# Altium schematic sheet audio-amp.SchDoc
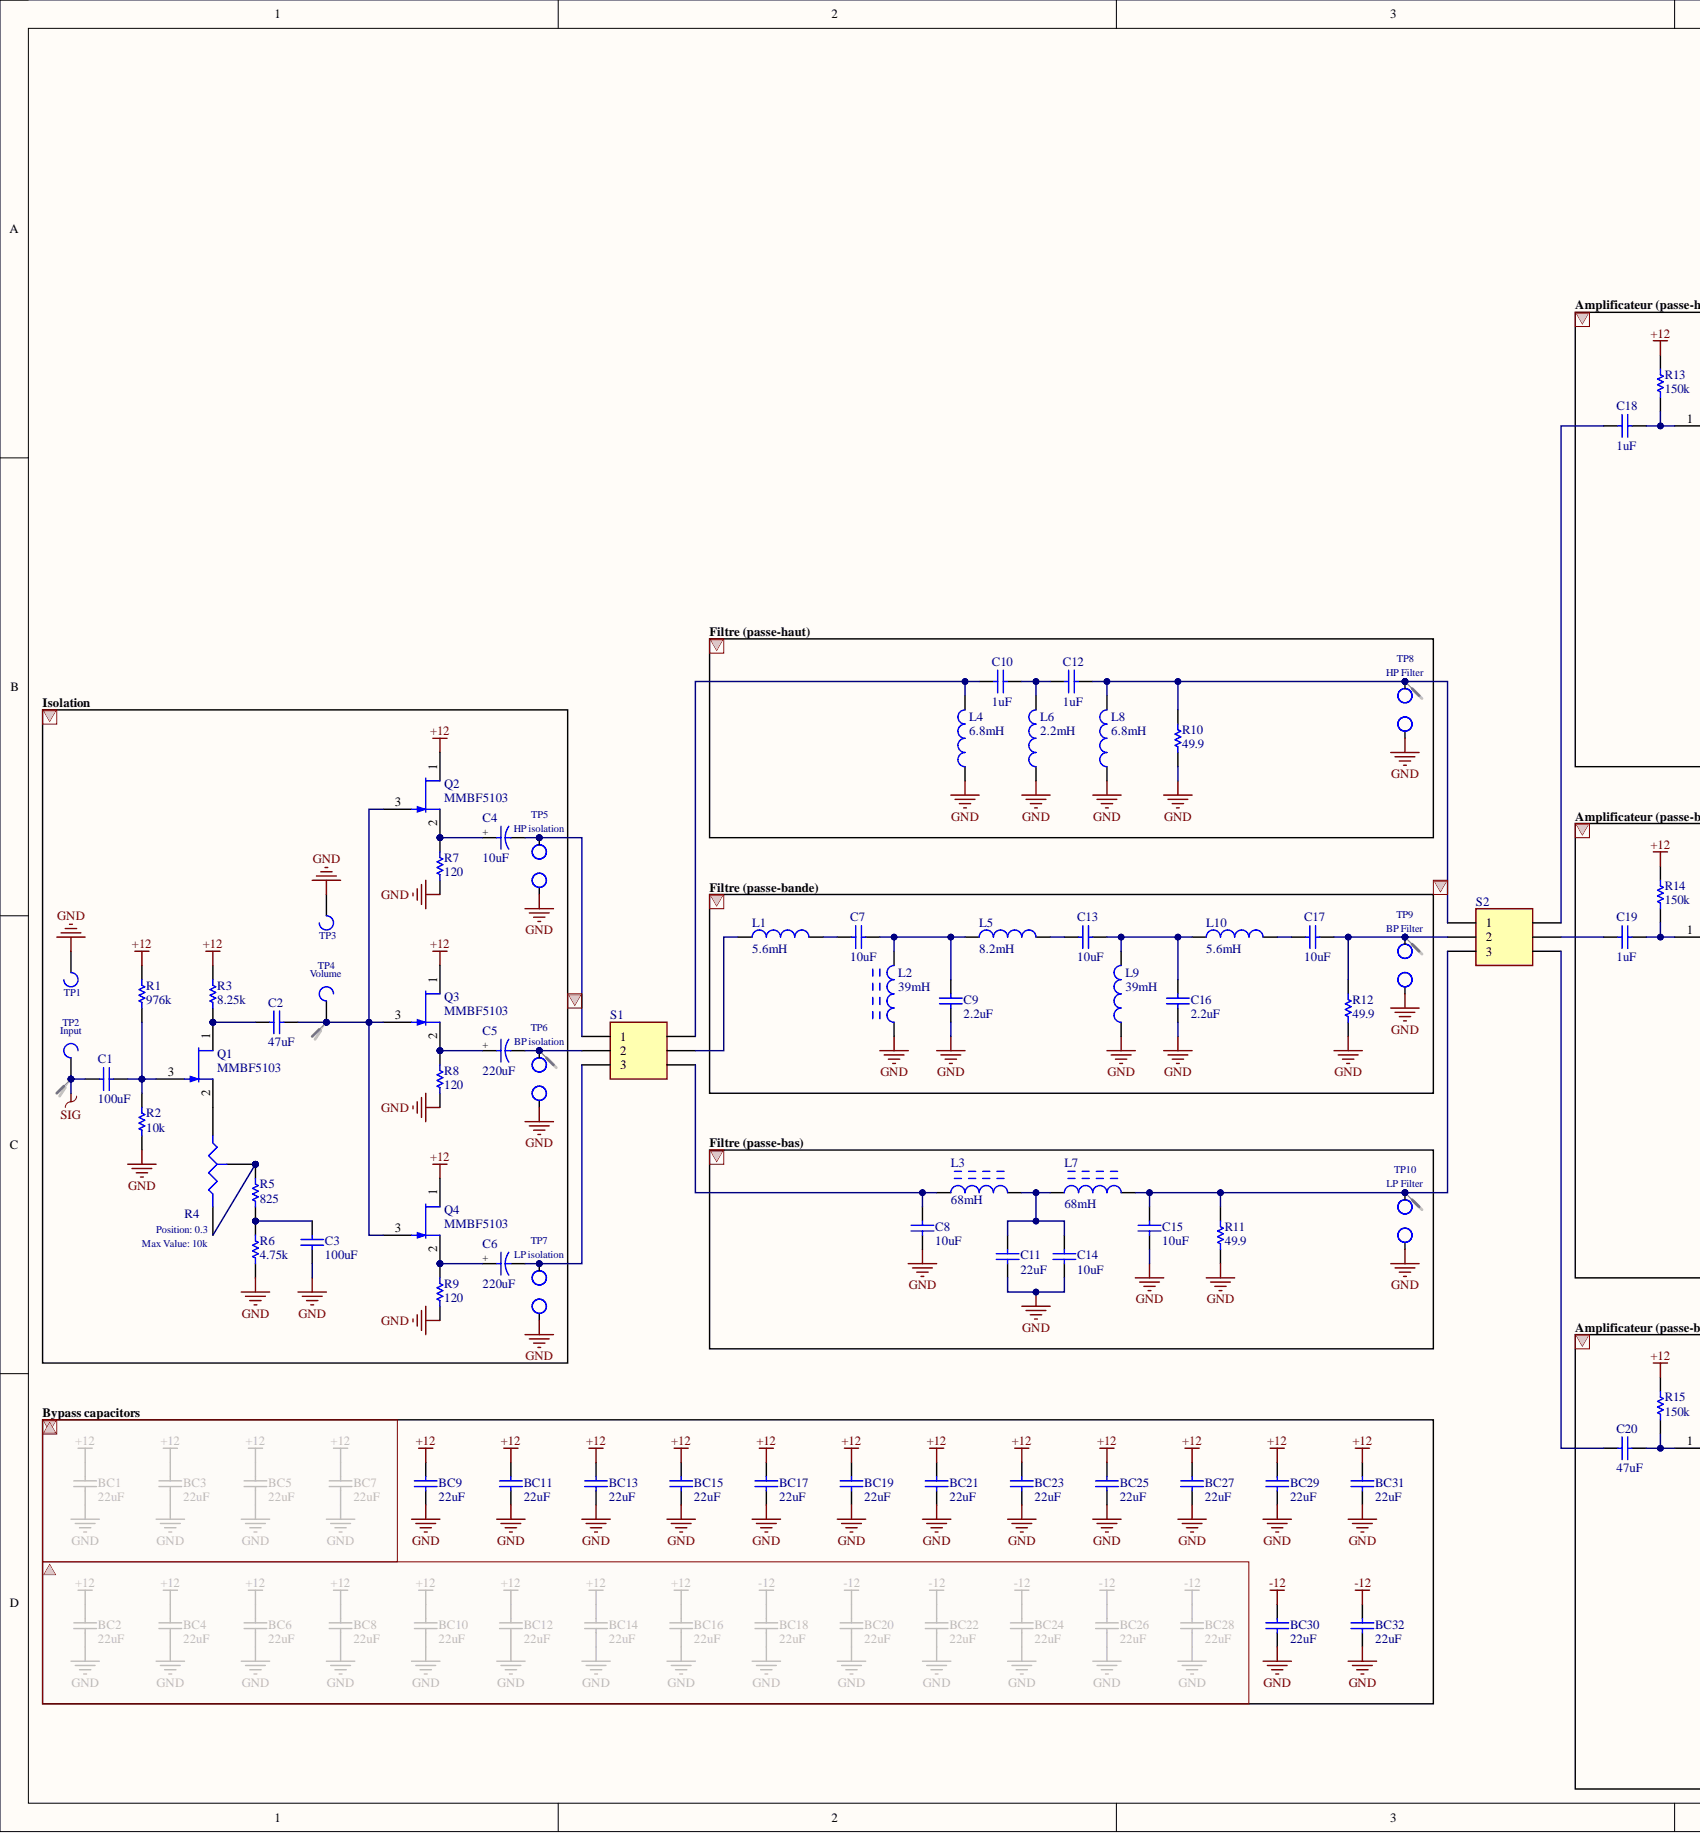
[diagram p0: audio-amp.SchDoc, left]
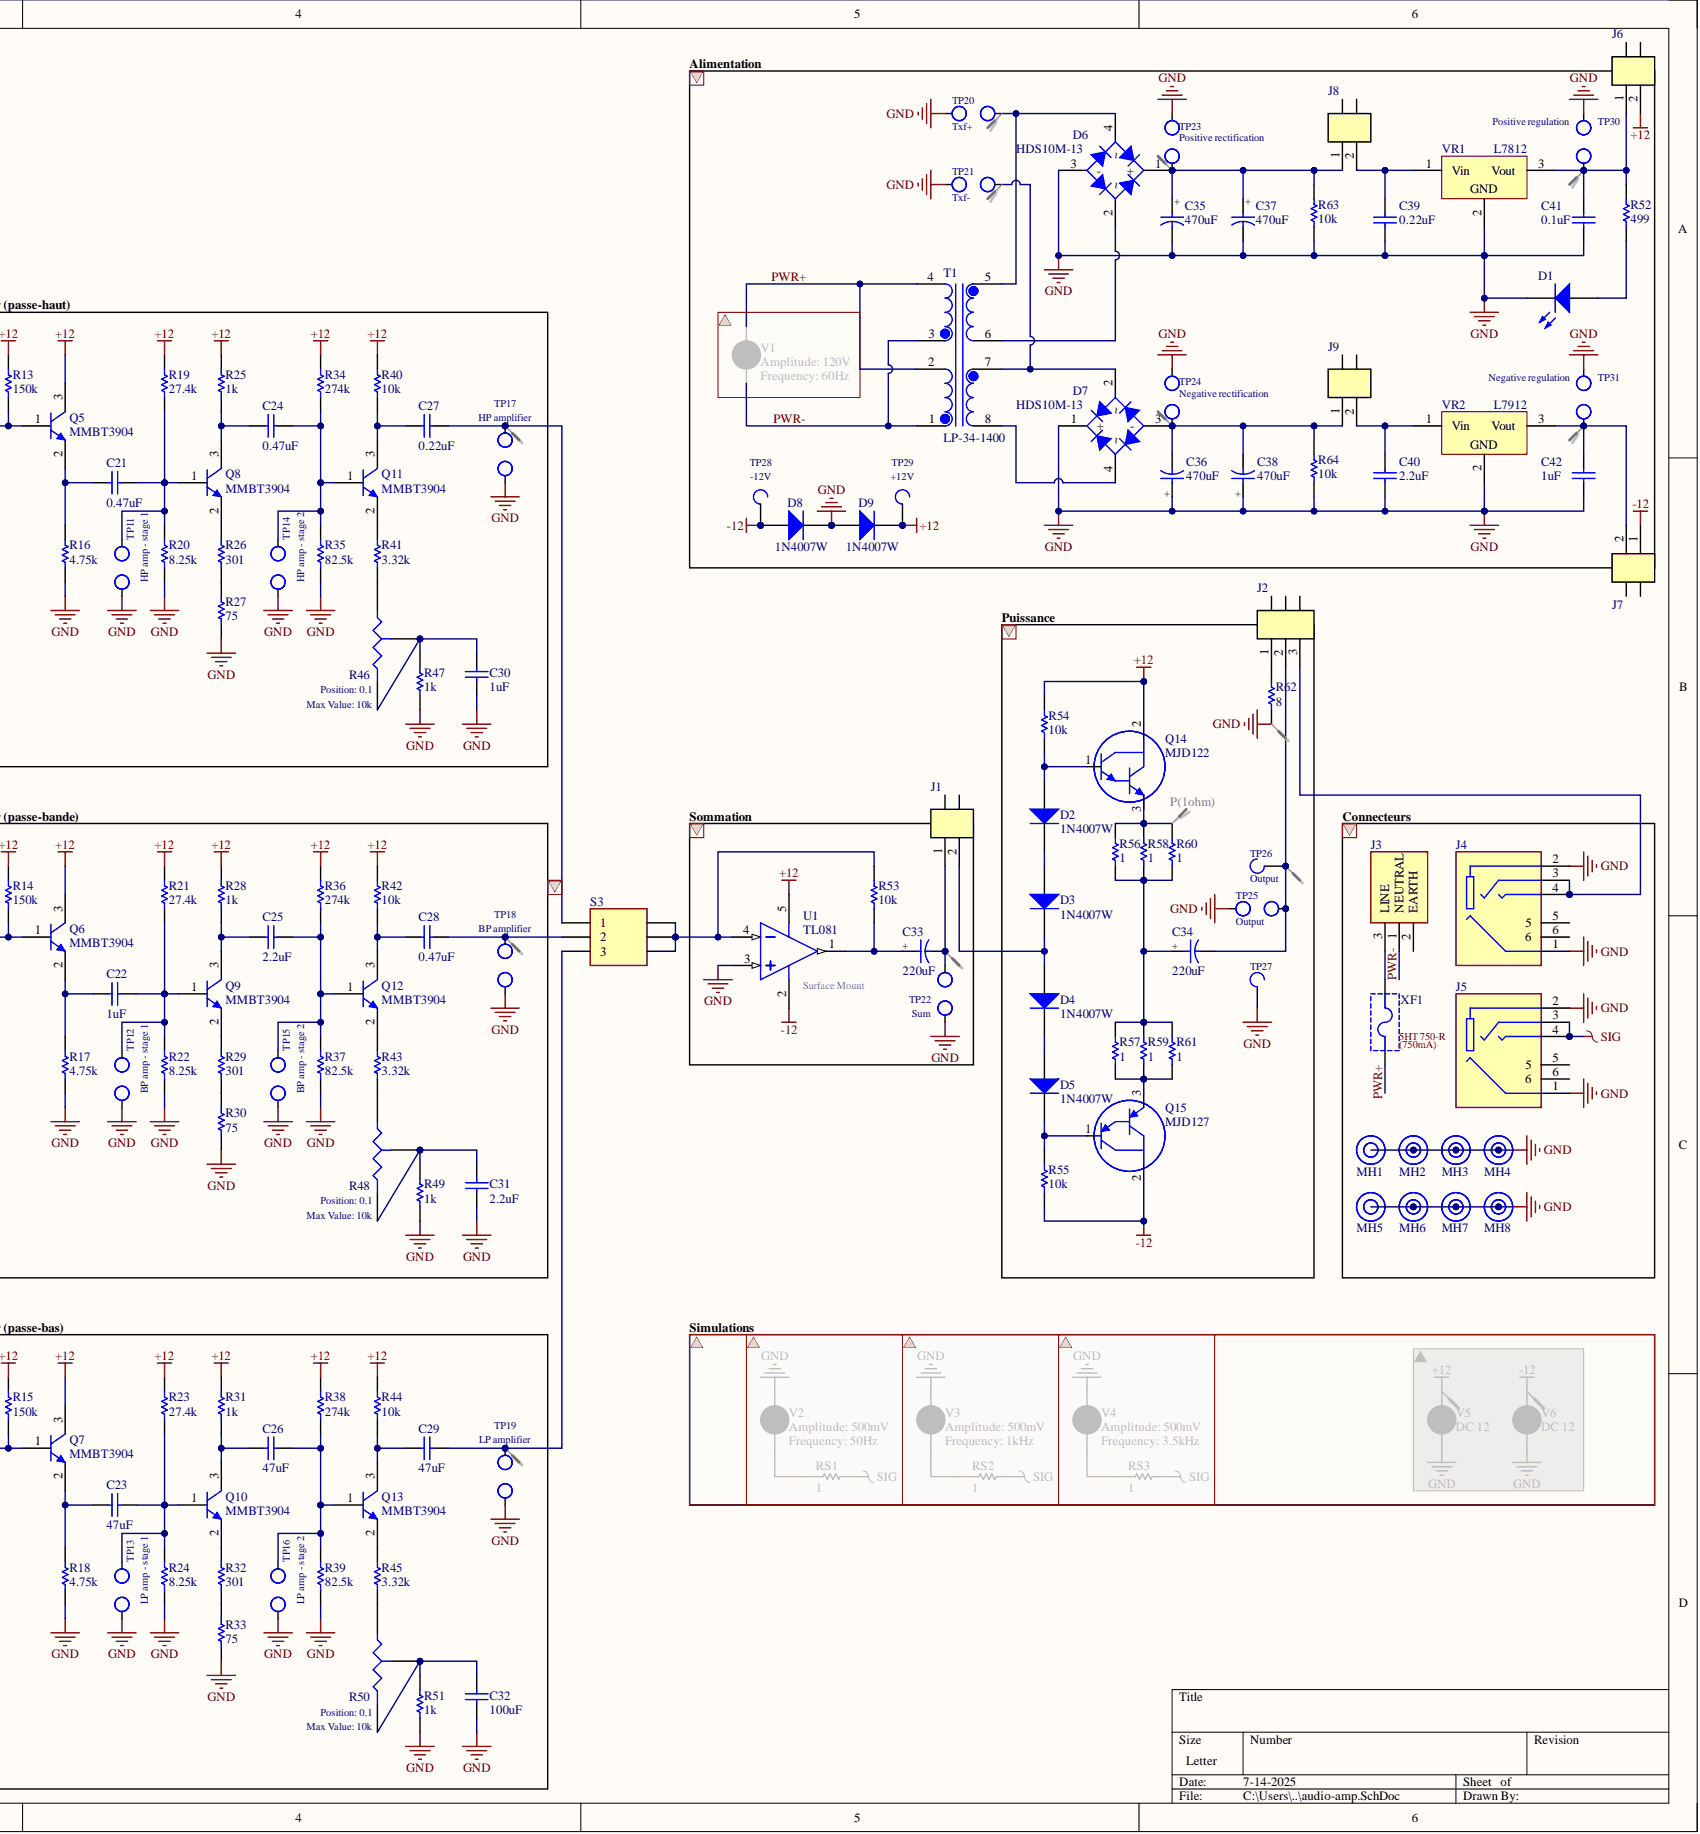
[diagram p1: audio-amp.SchDoc, right]

## Parts
BC9  value 22uF  [SMD-0805-M]  (hidden comment: Cap-SM-M)
BC11  value 22uF  [SMD-0805-M]  (hidden comment: Cap-SM-M)
BC13  value 22uF  [SMD-0805-M]  (hidden comment: Cap-SM-M)
BC15  value 22uF  [SMD-0805-M]  (hidden comment: Cap-SM-M)
BC17  value 22uF  [SMD-0805-M]  (hidden comment: Cap-SM-M)
BC19  value 22uF  [SMD-0805-M]  (hidden comment: Cap-SM-M)
BC21  value 22uF  [SMD-0805-M]  (hidden comment: Cap-SM-M)
BC23  value 22uF  [SMD-0805-M]  (hidden comment: Cap-SM-M)
BC25  value 22uF  [SMD-0805-M]  (hidden comment: Cap-SM-M)
BC27  value 22uF  [SMD-0805-M]  (hidden comment: Cap-SM-M)
BC29  value 22uF  [SMD-0805-M]  (hidden comment: Cap-SM-M)
BC30  value 22uF  [SMD-0805-M]  (hidden comment: Cap-SM-M)
BC31  value 22uF  [SMD-0805-M]  (hidden comment: Cap-SM-M)
BC32  value 22uF  [SMD-0805-M]  (hidden comment: Cap-SM-M)
C1  value 100uF  [SMD-1210-M]  (hidden comment: Cap-SM-M)
C2  value 47uF  [SMD-1210-M]  (hidden comment: Cap-SM-M)
C3  value 100uF  [SMD-1210-M]  (hidden comment: Cap-SM-M)
C4  value 10uF  [CAP-APA0606]  (hidden comment: Cap2-10uF-SM)
C5  value 220uF  [CAP-A768KS-M]  (hidden comment: Cap2-220uF-SM)
C6  value 220uF  [CAP-A768KS-M]  (hidden comment: Cap2-220uF-SM)
C7  value 10uF  [SMD-0805-M]  (hidden comment: Cap-SM-M)
C8  value 10uF  [SMD-0805-M]  (hidden comment: Cap-SM-M)
C9  value 2.2uF  [SMD-0805-M]  (hidden comment: Cap-SM-M)
C10  value 1uF  [SMD-0603-M]  (hidden comment: Cap-SM-M)
C11  value 22uF  [SMD-0805-M]  (hidden comment: Cap-SM-M)
C12  value 1uF  [SMD-0603-M]  (hidden comment: Cap-SM-M)
C13  value 10uF  [SMD-0805-M]  (hidden comment: Cap-SM-M)
C14  value 10uF  [SMD-0805-M]  (hidden comment: Cap-SM-M)
C15  value 10uF  [SMD-0805-M]  (hidden comment: Cap-SM-M)
C16  value 2.2uF  [SMD-0805-M]  (hidden comment: Cap-SM-M)
C17  value 10uF  [SMD-0805-M]  (hidden comment: Cap-SM-M)
C18  value 1uF  [SMD-0603-M]  (hidden comment: Cap-SM-M)
C19  value 1uF  [SMD-0603-M]  (hidden comment: Cap-SM-M)
C20  value 47uF  [SMD-1210-M]  (hidden comment: Cap-SM-M)
C21  value 0.47uF  [SMD-0805-M]  (hidden comment: Cap-SM-M)
C22  value 1uF  [SMD-0603-M]  (hidden comment: Cap-SM-M)
C23  value 47uF  [SMD-1210-M]  (hidden comment: Cap-SM-M)
C24  value 0.47uF  [SMD-0805-M]  (hidden comment: Cap-SM-M)
C25  value 2.2uF  [SMD-0805-M]  (hidden comment: Cap-SM-M)
C26  value 47uF  [SMD-1210-M]  (hidden comment: Cap-SM-M)
C27  value 0.22uF  [SMD-0805-M]  (hidden comment: Cap-SM-M)
C28  value 0.47uF  [SMD-0805-M]  (hidden comment: Cap-SM-M)
C29  value 47uF  [SMD-1210-M]  (hidden comment: Cap-SM-M)
C30  value 1uF  [SMD-0603-M]  (hidden comment: Cap-SM-M)
C31  value 2.2uF  [SMD-0805-M]  (hidden comment: Cap-SM-M)
C32  value 100uF  [SMD-1210-M]  (hidden comment: Cap-SM-M)
C33  value 220uF  [CAP-A768KS-M]  (hidden comment: Cap2-220uF-SM)
C34  value 220uF  [CAP-A768KS-M]  (hidden comment: Cap2-220uF-SM)
C35  value 470uF  [CAP-APD1012]  (hidden comment: Cap2-470uF-SM)
C36  value 470uF  [CAP-APD1012]  (hidden comment: Cap2-470uF-SM)
C37  value 470uF  [CAP-APD1012]  (hidden comment: Cap2-470uF-SM)
C38  value 470uF  [CAP-APD1012]  (hidden comment: Cap2-470uF-SM)
C39  value 0.22uF  [SMD-0805-M]  (hidden comment: Cap-SM-M)
C40  value 2.2uF  [SMD-0805-M]  (hidden comment: Cap-SM-M)
C41  value 0.1uF  [SMD-0805-M]  (hidden comment: Cap-SM-M)
C42  value 1uF  [SMD-0603-M]  (hidden comment: Cap-SM-M)
D1  LED  [LED_RED]  (value not drawn)
D2  1N4007W  [SOD-123FL]
D3  1N4007W  [SOD-123FL]
D4  1N4007W  [SOD-123FL]
D5  1N4007W  [SOD-123FL]
D6  HDS10M-13  [HDS10M13]
D7  HDS10M-13  [HDS10M13]
D8  1N4007W  [SOD-123FL]
D9  1N4007W  [SOD-123FL]
J1  HDR2x1  [HDR_1X2]  (value not drawn)
J2  HDR3x1  [HDR_1X3]  (value not drawn)
J3  703W-00/53  [QUALTEK_703W-00/53]  (value not drawn)
J4  Audio-Jack-Input  [Audio-Jack-Input]  (value not drawn)
J5  Audio-Jack-Input  [Audio-Jack-Input]  (value not drawn)
J6  HDR2x1  [HDR_1X2]  (value not drawn)
J7  HDR2x1  [HDR_1X2]  (value not drawn)
J8  HDR2x1  [HDR_1X2]  (value not drawn)
J9  HDR2x1  [HDR_1X2]  (value not drawn)
L1  value 5.6mH  [IND-9MM]  (hidden comment: Inductor)
L2  value 39mH  [TX-1779-1567-ND]  (hidden comment: Ind-Ferrite)
L3  value 68mH  [TX-1779-1567-ND]  (hidden comment: Ind-Ferrite)
L4  value 6.8mH  [IND-12MM]  (hidden comment: Inductor)
L5  value 8.2mH  [IND-9MM]  (hidden comment: Inductor)
L6  value 2.2mH  [IND-12MM]  (hidden comment: Inductor)
L7  value 68mH  [TX-1779-1567-ND]  (hidden comment: Ind-Ferrite)
L8  value 6.8mH  [IND-12MM]  (hidden comment: Inductor)
L9  value 39mH  [IND-9MM]  (hidden comment: Inductor)
L10  value 5.6mH  [IND-9MM]  (hidden comment: Inductor)
MH1  Mounting-Hole  [MH]  (value not drawn)
MH2  Mounting-Hole  [MH]  (value not drawn)
MH3  Mounting-Hole  [MH]  (value not drawn)
MH4  Mounting-Hole  [MH]  (value not drawn)
MH5  Mounting-Hole  [MH]  (value not drawn)
MH6  Mounting-Hole  [MH]  (value not drawn)
MH7  Mounting-Hole  [MH]  (value not drawn)
MH8  Mounting-Hole  [MH]  (value not drawn)
Q1  MMBF5103  [SOT-23-3]
Q2  MMBF5103  [SOT-23-3]
Q3  MMBF5103  [SOT-23-3]
Q4  MMBF5103  [SOT-23-3]
Q5  MMBT3904  [SOT-23-3]
Q6  MMBT3904  [SOT-23-3]
Q7  MMBT3904  [SOT-23-3]
Q8  MMBT3904  [SOT-23-3]
Q9  MMBT3904  [SOT-23-3]
Q10  MMBT3904  [SOT-23-3]
Q11  MMBT3904  [SOT-23-3]
Q12  MMBT3904  [SOT-23-3]
Q13  MMBT3904  [SOT-23-3]
Q14  MJD122  [TO-252-2L+HSS-C52]
Q15  MJD127  [TO-252-2L+HSS-C52]
R1  value 976k  [SMD-0805-M]  (hidden comment: Res-SM-M)
R2  value 10k  [SMD-1206-M]  (hidden comment: Res-SM-M)
R3  value 8.25k  [SMD-0805-M]  (hidden comment: Res-SM-M)
R4  Max Value: 10k  Position: 0.3  [TRIM_3361P]  (hidden comment: Potentiometer_SM)
R5  value 825  [SMD-0805-M]  (hidden comment: Res-SM-M)
R6  value 4.75k  [SMD-0805-M]  (hidden comment: Res-SM-M)
R7  value 120  [SMD-1206-M]  (hidden comment: Res-SM-M)
R8  value 120  [SMD-1206-M]  (hidden comment: Res-SM-M)
R9  value 120  [SMD-1206-M]  (hidden comment: Res-SM-M)
R10  value 49.9  [SMD-0805-M]  (hidden comment: Res-SM-M)
R11  value 49.9  [SMD-0805-M]  (hidden comment: Res-SM-M)
R12  value 49.9  [SMD-0805-M]  (hidden comment: Res-SM-M)
R13  value 150k  [SMD-1206-M]  (hidden comment: Res-SM-M)
R14  value 150k  [SMD-1206-M]  (hidden comment: Res-SM-M)
R15  value 150k  [SMD-1206-M]  (hidden comment: Res-SM-M)
R16  value 4.75k  [SMD-0805-M]  (hidden comment: Res-SM-M)
R17  value 4.75k  [SMD-0805-M]  (hidden comment: Res-SM-M)
R18  value 4.75k  [SMD-0805-M]  (hidden comment: Res-SM-M)
R19  value 27.4k  [SMD-1206-M]  (hidden comment: Res-SM-M)
R20  value 8.25k  [SMD-0805-M]  (hidden comment: Res-SM-M)
R21  value 27.4k  [SMD-1206-M]  (hidden comment: Res-SM-M)
R22  value 8.25k  [SMD-0805-M]  (hidden comment: Res-SM-M)
R23  value 27.4k  [SMD-1206-M]  (hidden comment: Res-SM-M)
R24  value 8.25k  [SMD-0805-M]  (hidden comment: Res-SM-M)
R25  value 1k  [SMD-0805-M]  (hidden comment: Res-SM-M)
R26  value 301  [SMD-0805-M]  (hidden comment: Res-SM-M)
R27  value 75  [SMD-0805-M]  (hidden comment: Res-SM-M)
R28  value 1k  [SMD-0805-M]  (hidden comment: Res-SM-M)
R29  value 301  [SMD-0805-M]  (hidden comment: Res-SM-M)
R30  value 75  [SMD-0805-M]  (hidden comment: Res-SM-M)
R31  value 1k  [SMD-0805-M]  (hidden comment: Res-SM-M)
R32  value 301  [SMD-0805-M]  (hidden comment: Res-SM-M)
R33  value 75  [SMD-0805-M]  (hidden comment: Res-SM-M)
R34  value 274k  [SMD-0805-M]  (hidden comment: Res-SM-M)
R35  value 82.5k  [SMD-1206-M]  (hidden comment: Res-SM-M)
R36  value 274k  [SMD-0805-M]  (hidden comment: Res-SM-M)
R37  value 82.5k  [SMD-1206-M]  (hidden comment: Res-SM-M)
R38  value 274k  [SMD-0805-M]  (hidden comment: Res-SM-M)
R39  value 82.5k  [SMD-1206-M]  (hidden comment: Res-SM-M)
R40  value 10k  [SMD-1206-M]  (hidden comment: Res-SM-M)
R41  value 3.32k  [SMD-0805-M]  (hidden comment: Res-SM-M)
R42  value 10k  [SMD-1206-M]  (hidden comment: Res-SM-M)
R43  value 3.32k  [SMD-0805-M]  (hidden comment: Res-SM-M)
R44  value 10k  [SMD-1206-M]  (hidden comment: Res-SM-M)
R45  value 3.32k  [SMD-0805-M]  (hidden comment: Res-SM-M)
R46  Max Value: 10k  Position: 0.1  [TRIM_3361P]  (hidden comment: Potentiometer_SM)
R47  value 1k  [SMD-0805-M]  (hidden comment: Res-SM-M)
R48  Max Value: 10k  Position: 0.1  [TRIM_3361P]  (hidden comment: Potentiometer_SM)
R49  value 1k  [SMD-0805-M]  (hidden comment: Res-SM-M)
R50  Max Value: 10k  Position: 0.1  [TRIM_3361P]  (hidden comment: Potentiometer_SM)
R51  value 1k  [SMD-0805-M]  (hidden comment: Res-SM-M)
R52  value 499  [SMD-0805-M]  (hidden comment: Res-SM-M)
R53  value 10k  [SMD-1206-M]  (hidden comment: Res-SM-M)
R54  value 10k  [SMD-1206-M]  (hidden comment: Res-SM-M)
R55  value 10k  [SMD-1206-M]  (hidden comment: Res-SM-M)
R56  value 1  [SMD-0805-M]  (hidden comment: Res-SM-M)
R57  value 1  [SMD-0805-M]  (hidden comment: Res-SM-M)
R58  value 1  [SMD-0805-M]  (hidden comment: Res-SM-M)
R59  value 1  [SMD-0805-M]  (hidden comment: Res-SM-M)
R60  value 1  [SMD-0805-M]  (hidden comment: Res-SM-M)
R61  value 1  [SMD-0805-M]  (hidden comment: Res-SM-M)
R62  value 8  [RES-2W]  (hidden comment: Res)
R63  value 10k  [SMD-1206-M]  (hidden comment: Res-SM-M)
R64  value 10k  [SMD-1206-M]  (hidden comment: Res-SM-M)
S1  219-3MSTR  [2193MSTR]  (value not drawn)
S2  219-3MSTR  [2193MSTR]  (value not drawn)
S3  219-3MSTR  [2193MSTR]  (value not drawn)
T1  LP-34-1400  [LP341400]
TP1  Comment  [KEYSTONE_5002_Black]  (value not drawn)
TP2  Input  [KEYSTONE_5002_White]
TP3  Comment  [KEYSTONE_5002_Black]  (value not drawn)
TP4  Volume  [KEYSTONE_5002_White]
TP5  HP isolation  [TP-Pad-Ref]
TP6  BP isolation  [TP-Pad-Ref]
TP7  LP isolation  [TP-Pad-Ref]
TP8  HP Filter  [TP-Pad-Ref]
TP9  BP Filter  [TP-Pad-Ref]
TP10  LP Filter  [TP-Pad-Ref]
TP11  HP amp - stage 1  [TP-Pad-Ref]
TP12  BP amp - stage 1  [TP-Pad-Ref]
TP13  LP amp - stage 1  [TP-Pad-Ref]
TP14  HP amp - stage 2  [TP-Pad-Ref]
TP15  BP amp - stage 2  [TP-Pad-Ref]
TP16  LP amp - stage 2  [TP-Pad-Ref]
TP17  HP amplifier  [TP-Pad-Ref]
TP18  BP amplifier  [TP-Pad-Ref]
TP19  LP amplifier  [TP-Pad-Ref]
TP20  Txf+  [TP-Pad-Ref]
TP21  Txf-  [TP-Pad-Ref]
TP22  Sum  [TP-Pad-Ref]
TP23  Positive rectification  [TP-Pad-Ref]
TP24  Negative rectification  [TP-Pad-Ref]
TP25  Output  [TP-Pad-Ref]
TP26  Output  [KEYSTONE_5002_White]
TP27  Comment  [KEYSTONE_5002_Black]  (value not drawn)
TP28  -12V  [KEYSTONE_5002_Yellow]
TP29  +12V  [KEYSTONE_5002_Red]
TP30  Positive regulation  [TP-Pad-Ref]
TP31  Negative regulation  [TP-Pad-Ref]
U1  TL081  MountingType: Surface Mount  [SOT-23-5]
VR1  L7812  [TO-263AB+HSS-C2591]
VR2  L7912  [TO-263AB+HSS-C2591]
XF1  696310001002  [696310001002]  (value not drawn)

## Nets
+12: BC9.2 BC11.2 BC13.2 BC15.2 BC17.2 BC19.2 BC21.2 BC23.2 BC25.2 BC27.2 BC29.2 BC31.2 D9.1 J6.2 Q2.1 Q3.1 Q4.1 Q5.3 Q6.3 Q7.3 Q14.2 R1.2 R3.2 R13.2 R14.2 R15.2 R19.2 R21.2 R23.2 R25.2 R28.2 R31.2 R34.2 R36.2 R38.2 R40.2 R42.2 R44.2 R54.2 TP29.TP U1.5
-12: BC30.2 BC32.2 D8.2 J7.1 Q15.2 R55.1 TP28.TP U1.2
GND: BC9.1 BC11.1 BC13.1 BC15.1 BC17.1 BC19.1 BC21.1 BC23.1 BC25.1 BC27.1 BC29.1 BC30.1 BC31.1 BC32.1 C3.1 C8.1 C9.1 C11.1 C14.1 C15.1 C16.1 C30.1 C31.1 C32.2 C35.2 C36.1 C37.2 C38.1 C39.1 C40.1 C41.1 C42.1 D1.2 D6.3(-) D7.1(+) D8.1 D9.2 J4.1 J4.2 J5.1 J5.2 L2.1 L4.1 L6.1 L8.1 L9.1 MH1.1 MH2.1 MH3.1 MH4.1 MH5.1 MH6.1 MH7.1 MH8.1 R2.1 R6.1 R7.1 R8.1 R9.1 R10.1 R11.1 R12.1 R16.1 R17.1 R18.1 R20.1 R22.1 R24.1 R27.1 R30.1 R33.1 R35.1 R37.1 R39.1 R47.1 R49.1 R51.1 R62.2 R63.2 R64.2 TP1.TP TP3.TP TP5.2 TP6.2 TP7.2 TP8.2 TP9.2 TP10.2 TP11.2 TP12.2 TP13.2 TP14.2 TP15.2 TP16.2 TP17.2 TP18.2 TP19.2 TP20.2 TP21.2 TP22.2 TP23.2 TP24.2 TP25.2 TP27.TP TP30.2 TP31.2 U1.3 VR1.2 VR2.2
SIG: C1.2 J5.3 J5.4 TP2.TP
PWR+: T1.2 T1.4 XF1.1
PWR-: J3.1 T1.1 T1.3
NetC1_1: C1.1 Q1.3 R1.1 R2.2
NetC2_1: C2.1 Q1.1 R3.1
NetC2_2: C2.2 Q2.3 Q3.3 Q4.3 TP4.TP
NetC3_2: C3.2 R5.1 R6.2
NetC4_1: C4.1 Q2.2 R7.2
NetC4_2: C4.2 S1.1 TP5.1
NetC5_1: C5.1 Q3.2 R8.2
NetC5_2: C5.2 S1.2 TP6.1
NetC6_1: C6.1 Q4.2 R9.2
NetC6_2: C6.2 S1.3 TP7.1
NetC7_1: C7.1 L1.2
NetC7_2: C7.2 C9.2 L2.2 L5.1
NetC8_2: C8.2 L3.1 S1.4
NetC10_1: C10.1 L4.2 S1.6
NetC10_2: C10.2 C12.1 L6.2
NetC11_2: C11.2 C14.2 L3.2 L7.1
NetC12_2: C12.2 L8.2 R10.2 S2.1 TP8.1
NetC13_1: C13.1 L5.2
NetC13_2: C13.2 C16.2 L9.2 L10.1
NetC15_2: C15.2 L7.2 R11.2 S2.3 TP10.1
NetC17_1: C17.1 L10.2
NetC17_2: C17.2 R12.2 S2.2 TP9.1
NetC18_1: C18.1 S2.6
NetC18_2: C18.2 Q5.1 R13.1
NetC19_1: C19.1 S2.5
NetC19_2: C19.2 Q6.1 R14.1
NetC20_1: C20.1 S2.4
NetC20_2: C20.2 Q7.1 R15.1
NetC21_1: C21.1 Q5.2 R16.2
NetC21_2: C21.2 Q8.1 R19.1 R20.2 TP11.1
NetC22_1: C22.1 Q6.2 R17.2
NetC22_2: C22.2 Q9.1 R21.1 R22.2 TP12.1
NetC23_1: C23.1 Q7.2 R18.2
NetC23_2: C23.2 Q10.1 R23.1 R24.2 TP13.1
NetC24_1: C24.1 Q8.3 R25.1
NetC24_2: C24.2 Q11.1 R34.1 R35.2 TP14.1
NetC25_1: C25.1 Q9.3 R28.1
NetC25_2: C25.2 Q12.1 R36.1 R37.2 TP15.1
NetC26_1: C26.1 Q10.3 R31.1
NetC26_2: C26.2 Q13.1 R38.1 R39.2 TP16.1
NetC27_1: C27.1 S3.1 TP17.1
NetC27_2: C27.2 Q11.3 R40.1
NetC28_1: C28.1 S3.2 TP18.1
NetC28_2: C28.2 Q12.3 R42.1
NetC29_1: C29.1 S3.3 TP19.1
NetC29_2: C29.2 Q13.3 R44.1
NetC30_2: C30.2 R46.2 R46.3 R47.2
NetC31_2: C31.2 R48.2 R48.3 R49.2
NetC32_1: C32.1 R50.2 R50.3 R51.2
NetC33_1: C33.1 R53.1 U1.1
NetC33_2: C33.2 J1.1 TP22.1
NetC34_1: C34.1 R56.1 R57.2 R58.1 R59.2 R60.1 R61.2
NetC34_2: C34.2 J2.2 TP25.1 TP26.TP
NetC35_1: C35.1 C37.1 D6.1(+) J8.1 R63.1 TP23.1
NetC36_2: C36.2 C38.2 D7.3(-) J9.1 R64.1 TP24.1
NetC39_2: C39.2 J8.2 VR1.1
NetC40_2: C40.2 J9.2 VR2.1
NetC41_2: C41.2 J6.1 R52.1 TP30.1 VR1.3
NetC42_2: C42.2 J7.2 TP31.1 VR2.3
NetD1_1: D1.1 R52.2
NetD2_1: D2.1 D3.2
NetD2_2: D2.2 Q14.1 R54.1
NetD3_1: D3.1 D4.2 J1.2
NetD4_1: D4.1 D5.2
NetD5_1: D5.1 Q15.1 R55.2
NetD6_2: D6.2(~) T1.6
NetD6_4: D6.4(~) T1.5 TP20.1
NetD7_2: D7.2(~) T1.7 TP21.1
NetD7_4: D7.4(~) T1.8
NetJ2_1: J2.1 R62.1
NetJ2_3: J2.3 J4.3 J4.4
NetJ3_3: J3.3 XF1.2
NetL1_1: L1.1 S1.5
NetQ1_2: Q1.2 R4.1
NetQ8_2: Q8.2 R26.2
NetQ9_2: Q9.2 R29.2
NetQ10_2: Q10.2 R32.2
NetQ11_2: Q11.2 R41.2
NetQ12_2: Q12.2 R43.2
NetQ13_2: Q13.2 R45.2
NetQ14_3: Q14.3 R56.2 R58.2 R60.2
NetQ15_3: Q15.3 R57.1 R59.1 R61.1
NetR4_2: R4.2 R4.3 R5.2
NetR26_1: R26.1 R27.2
NetR29_1: R29.1 R30.2
NetR32_1: R32.1 R33.2
NetR41_1: R41.1 R46.1
NetR43_1: R43.1 R48.1
NetR45_1: R45.1 R50.1
NetR53_2: R53.2 S3.4 S3.5 S3.6 U1.4

Not connected: J3 2; J4 5 6; J5 5 6

## Library data of the parts
BC9 BC11 BC13 BC15 BC17 BC19 BC21 BC23 BC25 BC27 BC29-BC32 C1-C3 C7-C32 C39-C42 = Cap-SM-M: Capacitor - Surface Mount larger pads; Component Kind: Standard; MountingType: Surface Mount; Signal Integrity: Cap; Simulation: CAP; Library Name: CABS_2025.IntLib
C4 = Cap2-10uF-SM: 10µF 50V Aluminum - Polymer Capacitors Radial, Can - SMD 60mOhm 5000Hrs @ 105°C; Model: APA0606100M050R; Component Kind: Standard; ComponentLink1Description: Datasheet; ComponentLink1URL: https://datasheets.kyocera-avx.com/kyocera-avx-apa-series.pdf; ComponentLink2Description: Manufacturer; ComponentLink2URL: https://www.digikey.ca/en/products/detail/kyocera-avx/apa0606100m050r/15195398; MountingType: Surface Mount; Signal Integrity: Cap; Simulation: CAP; Library Name: CABS_2025.IntLib
C5 C6 C33 C34 = Cap2-220uF-SM: 220µF 35V Aluminum - Polymer Capacitors Radial, Can - SMD 24mOhm 2000Hrs @ 125°C; Model: A768KS227M1VLAE024; Component Kind: Standard; ComponentLink1Description: Datasheet; ComponentLink1URL: https://content.kemet.com/datasheets/KEM_A4088_A768.pdf; ComponentLink2Description: Manufacturer; ComponentLink2URL: https://www.digikey.ca/en/products/detail/kemet/a768ks227m1vlae024/12704040; MountingType: Surface Mount; Signal Integrity: Cap; Simulation: CAP; Library Name: CABS_2025.IntLib
C35-C38 = Cap2-470uF-SM: 470µF 35V Aluminum - Polymer Capacitors Radial, Can - SMD 27mOhm 2000Hrs @ 105°C; Model: APD1012471M035R; Component Kind: Standard; ComponentLink1Description: Datasheet; ComponentLink1URL: https://datasheets.kyocera-avx.com/kyocera-avx-apd-series.pdf; ComponentLink2Description: Manufacturer; ComponentLink2URL: https://www.digikey.ca/en/products/detail/kyocera-avx/apd1012471m035r/14555991; MountingType: Surface Mount; Signal Integrity: Cap; Simulation: CAP; Library Name: CABS_2025.IntLib
D1 = LED: Typical LED; Component Kind: Standard; MountingType: Through Hole; Simulation: LED2; Library Name: CABS_2025.IntLib
D2-D5 D8 D9 = 1N4007W: Diode 1000V 1A Surface Mount SOD-123FL; Component Kind: Standard; ComponentLink1Description: Datasheet; ComponentLink1URL: https://mm.digikey.com/Volume0/opasdata/d220001/medias/docus/6440/1N4007W.pdf; ComponentLink2Description: Manufacturer; ComponentLink2URL: https://www.digikey.ca/en/products/detail/evvo/1n4007w/21407138; DigiKey Part Number: 5272-1N4007WTR-ND; Library Name: Schlib1.SchLib; MountingType: Surface Mount; PackageReference: SOD-123F
D6 D7 = HDS10M-13: Bridge Rectifier Single Phase Standard 1 kV Surface Mount HDS; Component Kind: Standard; ComponentLink1Description: Datasheet; ComponentLink1URL: https://www.diodes.com/assets/Datasheets/HDS10M.pdf; ComponentLink2Description: Manufacturer; ComponentLink2URL: https://www.digikey.ca/en/products/detail/diodes-incorporated/hds10m-13/8537822; DigiKey Part Number: HDS10M-13DITR-ND; Library Name: Schlib1.SchLib; MountingType: Surface Mount
J1 J6-J9 = HDR2x1: Connector Header Through Hole 2 position 0.100" (2.54mm); Manufacturer: TE Connectivity; Manufacturer Part Number: 5-146280-3; Component Kind: Standard; ComponentLink1Description: Datasheet; ComponentLink1URL: https://www.te.com/usa-en/product-5-146280-2.datasheet.pdf; ComponentLink2Description: Manufacturer; ComponentLink2URL: https://www.digikey.ca/en/products/detail/te-connectivity-amp-connectors/5-146280-2/2275896?s=N4IgTCBcDaIKwFoCMAWAbGAHABgRAugL5A; MountingType: Through Hole; Simulation: HEADER_2PIN; Library Name: CABS_2025.IntLib
J2 = HDR3x1: Connector Header Through Hole 3 position 0.100" (2.54mm); Manufacturer: TE Connectivity; Manufacturer Part Number: 5-146280-3; Component Kind: Standard; ComponentLink1Description: Datasheet; ComponentLink1URL: https://www.te.com/usa-en/product-5-146280-3.datasheet.pdf; ComponentLink2Description: Manufacturer; ComponentLink2URL: https://www.digikey.ca/en/products/detail/te-connectivity-amp-connectors/5-146280-3/2275898; MountingType: Through Hole; Simulation: HEADER_3PIN; Library Name: CABS_2025.IntLib
J3 = 703W-00/53: Power Entry Connector Receptacle, Male Blades IEC 320-C14 Panel Mount, Flange; Through Hole, Right Angle; Component Kind: Standard; ComponentLink1Description: Manufacturer; ComponentLink1URL: https://www.digikey.ca/en/products/detail/qualtek/703W-00-53/245560; ComponentLink2Description: Datasheet; ComponentLink2URL: https://www.qualtekusa.com/images/AC_Receptacles/pdfs/703w0053.pdf; DigiKey Part Number: Q219-ND; Library Name: Schlib1.SchLib; MountingType: Through Hole; Simulation: THREE_PIN
J4 J5 = Audio-Jack-Input: Connector, 3C audio jack, 3.5xL14 mm, SMT mount, silver plated; Component Kind: Standard; ComponentLink1Description: Manufacturer; ComponentLink1URL: https://www.digikey.ca/en/products/detail/tensility-international-corp/54-00178/12140149; ComponentLink2Description: Datasheet; ComponentLink2URL: https://tensility.s3.us-west-2.amazonaws.com/uploads/pdffiles/54-00178.pdf; DigiKey Part Number: 839-54-00178TR-ND; Library Name: Schlib1.SchLib; MountingType: Surface Mount; Simulation: SIX_PIN
L1 L4-L6 L8-L10 = Inductor: Unshielded Drum Core, Wirewound Inductor Radial, Vertical Cylinder; Component Kind: Standard; MountingType: Through Hole; Signal Integrity: Inductor; Simulation: INDUCTOR; Library Name: CABS_2025.IntLib
L2 L3 L7 = Ind-Ferrite: Inductance Made With Epoxy Ferrite Core Toroid; Manufacturer: Ferroxcube; Component Kind: Standard; MountingType: Through Hole; Signal Integrity: Inductor; Simulation: INDUCTOR; Library Name: CABS_2025.IntLib
MH1-MH8 = Mounting-Hole: 2.8mm mounting hole with 4.5mm pads; Component Kind: Standard; Library Name: Schlib1.SchLib
Q1-Q4 = MMBF5103: N-Channel JFET 40V SOT23-3; Component Kind: Standard; ComponentLink1Description: Datasheet; ComponentLink1URL: https://www.onsemi.com/pdf/datasheet/mmbf5103-d.pdf; ComponentLink2Description: Manufacturer; ComponentLink2URL: https://www.digikey.ca/en/products/detail/onsemi/mmbf5103/1049146; DigiKey Part Number: MMBF5103TR-ND; MountingType: Surface Mount; Simulation: MMBF5103; Library Name: CABS_2025.IntLib
Q5-Q13 = MMBT3904: BJT NPN 40V 200mA 300MHz 300mW Surface Mount SOT-23-3 (TO-236); Component Kind: Standard; ComponentLink1Description: Datasheet; ComponentLink1URL: https://www.onsemi.com/pdf/datasheet/mmbt3904lt1-d.pdf; ComponentLink2Description: Manufacturer; ComponentLink2URL: https://www.digikey.ca/en/products/detail/onsemi/mmbt3904lt3g/919602; DigiKey Part Number: MMBT3904LT3GOSTR-ND; MountingType: Surface Mount; PackageReference: TO-236; Simulation: MMBT3904; Library Name: CABS_2025.IntLib
Q14 = MJD122: Bipolar (BJT) Transistor NPN - Darlington 100V 8A 1.5W Surface Mount TO-252-2L; Component Kind: Standard; ComponentLink1Description: Datasheet; ComponentLink1URL: https://mm.digikey.com/Volume0/opasdata/d220001/medias/docus/6128/MJD122.pdf; ComponentLink2Description: Manufacturer; ComponentLink2URL: https://www.digikey.ca/en/products/detail/evvo/MJD122/22482068; DigiKey Part Number: 5272-MJD122TR-ND; Library Name: Schlib1.SchLib; MountingType: Surface Mount; PackageReference: TO-252-2L; Simulation: MJD122
Q15 = MJD127: Bipolar (BJT) Transistor PNP - Darlington 100V 8A 1.5W Surface Mount TO-252-2L; Component Kind: Standard; ComponentLink1Description: Datasheet; ComponentLink1URL: https://mm.digikey.com/Volume0/opasdata/d220001/medias/docus/1098/MJD127.pdf; ComponentLink2Description: Manufacturer; ComponentLink2URL: https://www.digikey.ca/en/products/detail/evvo/MJD127/22482063; DigiKey Part Number: 5272-MJD127TR-ND; Library Name: Schlib1.SchLib; MountingType: Surface Mount; PackageReference: TO-252-2L; Simulation: MJD127
R1-R3 R5-R45 R47 R49 R51-R61 R63 R64 = Res-SM-M: Resistor - Surface Mount larger pads; Component Kind: Standard; LatestRevisionDate: 17-Jul-2002; LatestRevisionNote: Re-released for DXP Platform.; MountingType: Surface Mount; PackageDescription: Axial Device, Thru-Hole; 2 Leads; 0.3 in Pin Spacing; PackageReference: AXIAL-0.3; Published: 8-Jun-2000; Signal Integrity: Res; Simulation: RESISTOR; Library Name: CABS_2025.IntLib; Publisher: Altium Limited
R4 R46 R48 R50 = Potentiometer_SM: 10kOhms 500mW Gull Wing Surface Mount Trimmer Potentiometer; Sim Note: Enter the Resistance value and the set point into parameter fields.; Component Kind: Standard; ComponentLink1Description: Datasheet; ComponentLink1URL: https://www.bourns.com/docs/Product-Datasheets/3361.pdf; ComponentLink2Description: Manufacturer; ComponentLink2URL: https://www.digikey.ca/en/products/detail/bourns-inc/3361p-1-103glf/1088368; DigiKey Part Number: 3361P-103GLFTR-ND; MountingType: Surface Mount; Signal Integrity: Res Tap; Simulation: POT; Library Name: CABS_2025.IntLib
R62 = Res: Resistor; Component Kind: Standard; LatestRevisionDate: 17-Jul-2002; LatestRevisionNote: Re-released for DXP Platform.; MountingType: Through Hole; PackageDescription: Axial Device, Thru-Hole; 2 Leads; 0.3 in Pin Spacing; PackageReference: AXIAL-0.3; Published: 8-Jun-2000; Signal Integrity: Res; Simulation: RESISTOR; Library Name: CABS_2025.IntLib; Publisher: Altium Limited
S1-S3 = 219-3MSTR: Dip Switch SPST 3 Position Surface Mount Slide (Standard) Actuator 100mA 20VDC; Component Kind: Standard; ComponentLink1Description: Manufacturer; ComponentLink1URL: https://www.digikey.ca/en/products/detail/cts-electrocomponents/219-3MSTR/5224026; ComponentLink2Description: Datasheet; ComponentLink2URL: https://www.ctscorp.com/Files/DataSheets/Switches/DIP-Switches/CTS-Switches-DIP-219-Series-Datasheet.pdf; DigiKey Part Number: 119-219-3MSTR-ND; Height: 3.85mm; Library Name: Schlib1.SchLib; MountingType: Surface Mount; Simulation: DIP3
T1 = LP-34-1400: PWR XFMR SEMI-TORO 48VA TH (Parallel 17V@2.8A, Series 34V@1.4A); Component Kind: Standard; ComponentLink1Description: Datasheet; ComponentLink1URL: https://www.belfuse.com/media/datasheets/products/transformers/ds-st-lp-series.pdf; ComponentLink2Description: Manufacturer; ComponentLink2URL: https://www.digikey.ca/en/products/detail/signal-transformer/lp-34-1400/1020903; DigiKey Part Number: 595-1341-ND; Library Name: Schlib1.SchLib; MountingType: Through Hole; Simulation: LP-34-1400
TP1-TP4 TP26-TP29 = TP-Loop: PC Test Point, Miniature Phosphor Bronze Silver Plating Through Hole Mounting Type; Component Kind: Standard; ComponentLink1Description: Manufacturer; ComponentLink1URL: https://www.digikey.ca/en/products/detail/keystone-electronics/5002/255328; ComponentLink2Description: Datasheet; ComponentLink2URL: https://www.keyelco.com/userAssets/file/M65p56.pdf; DigiKey Part Number: 36-5002-ND; Library Name: Schlib1.SchLib; MountingType: Through Hole; Simulation: ONE_PIN
TP5-TP25 TP30 TP31 = TP-Pad-Ref: Component Kind: Standard; Library Name: Schlib1.SchLib; Simulation: TWO_PIN
U1 = TL081HIDBVR: General-Purpose J-FET Single Operational Amplifier; Component Kind: Standard; ComponentLink2Description: Datasheet; ComponentLink2URL: http://www.st.com/stonline/products/literature/ds/2299/tl081.pdf; ComponentLink3Description: Manufacturer; ComponentLink3URL: https://www.digikey.ca/en/products/detail/texas-instruments/tl081hidbvr/15205166; DigiKey Part Number: 296-TL081HIDBVRTR-ND; PackageReference: SOT-23-5; Simulation: TL081; Library Name: CABS_2025.IntLib
VR1 = L7812CD2T-TR: Linear Voltage Regulator IC Positive Fixed +12V Output 1.5A D2PAK; Component Kind: Standard; ComponentLink1Description: Manufacturer; ComponentLink1URL: https://www.digikey.ca/en/products/detail/stmicroelectronics/L7812CD2T-TR/585702; ComponentLink2Description: Datasheet; ComponentLink2URL: https://www.st.com/content/ccc/resource/technical/document/datasheet/41/4f/b3/b0/12/d4/47/88/CD00000444.pdf/files/CD00000444.pdf/jcr:content/translations/en.CD00000444.pdf; DigiKey Part Number: 497-1178-2-ND; Library Name: Schlib1.SchLib; MountingType: Surface Mount; PackageReference: TO-263AB; Simulation: LM7812
VR2 = L7912CD2T-TR: Linear Voltage Regulator IC Negative Fixed -12V Output 1.5A D2PAK; Component Kind: Standard; ComponentLink1Description: Datasheet; ComponentLink1URL: https://mm.digikey.com/Volume0/opasdata/d220001/medias/docus/1053/L79xxC_Rev21_May2012.pdf; ComponentLink2Description: Manufacturer; ComponentLink2URL: https://www.digikey.ca/en/products/detail/stmicroelectronics/L7912CD2T-TR/585739; DigiKey Part Number: 497-1215-2-ND; Library Name: Schlib1.SchLib; MountingType: Surface Mount; PackageReference: TO-263AB; Simulation: LM7912
XF1 = 696310001002: WR-FSH Shocksafe Fuse Holder Turn and Lock Cover for PCB; Manufacturer: Wurth Elektronik; Fuse Size: 5x20mm; Itrip: 0.75; Category: Fuse Holder; Component Kind: Standard; ComponentLink1Description: Website Link; ComponentLink1URL: https://www.we-online.com/redexpert/article/696310001002?ad; ComponentLink2Description: Datasheet Link; ComponentLink2URL: https://www.we-online.com/redexpert/spec/696310001002?ad; DigiKey Part Number: 732-11384-ND; Library Name: Fuses.SchLib; Manufacturer Part Number: 696310001002; Match Code: WR-FSH; Mount: THT; MountingType: Through Hole; Operating Temperature Max: 120°C; Operating Temperature Min: -30°C; Packaging: Box; Simulation: FUSE_750mA
